# Altium schematic sheet HBridge.SchDoc
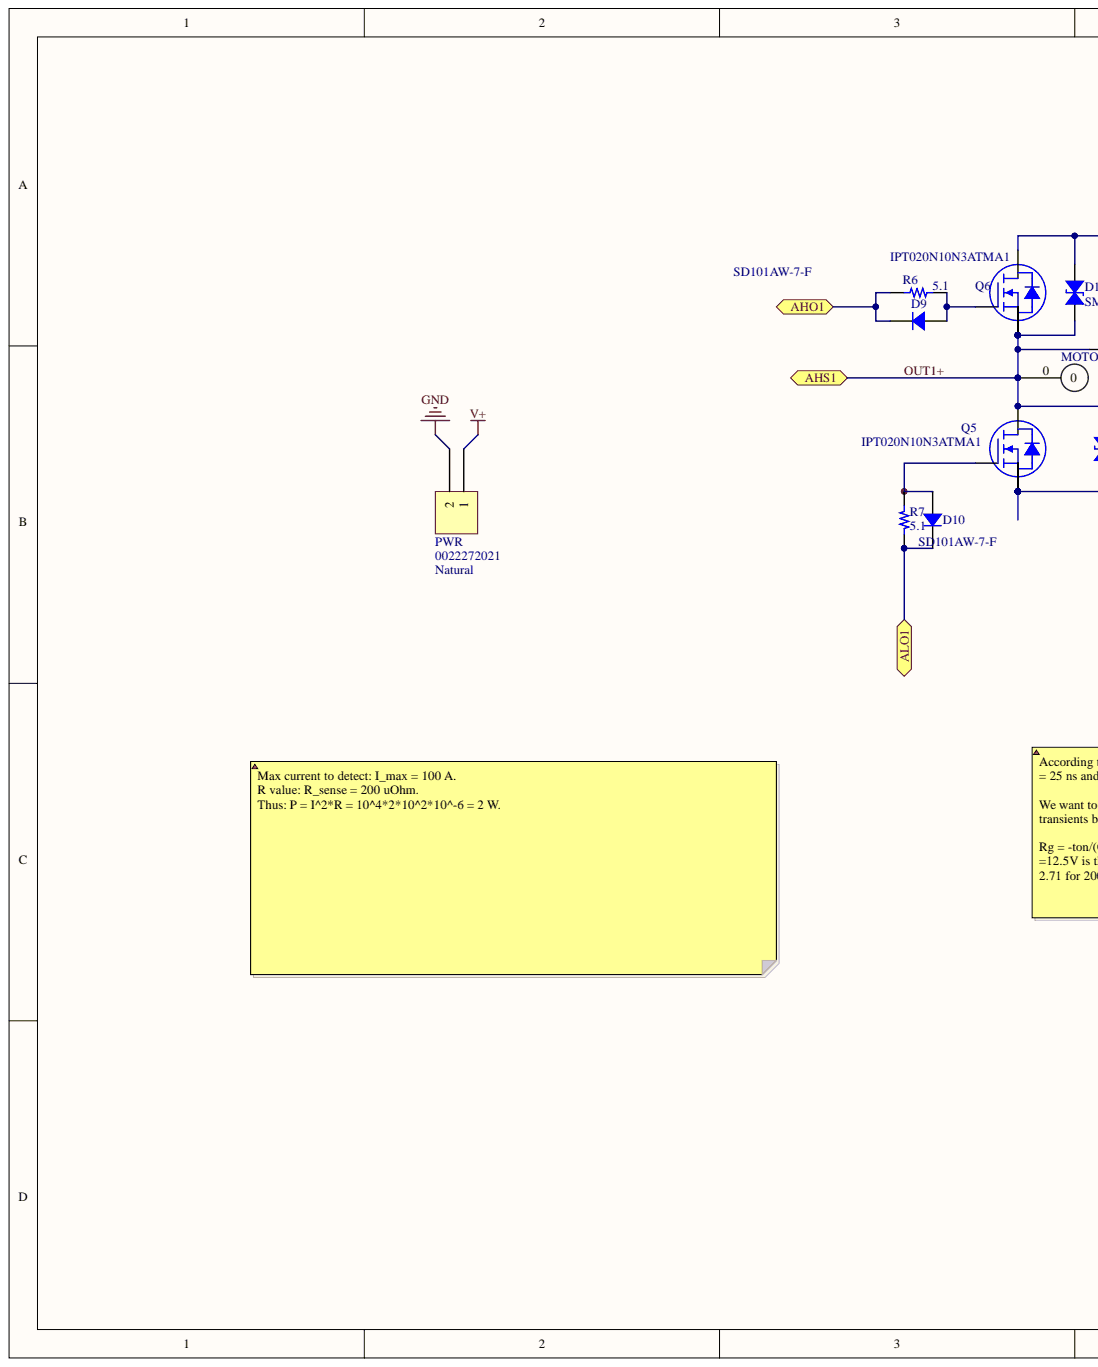
[diagram p0: HBridge.SchDoc, left]
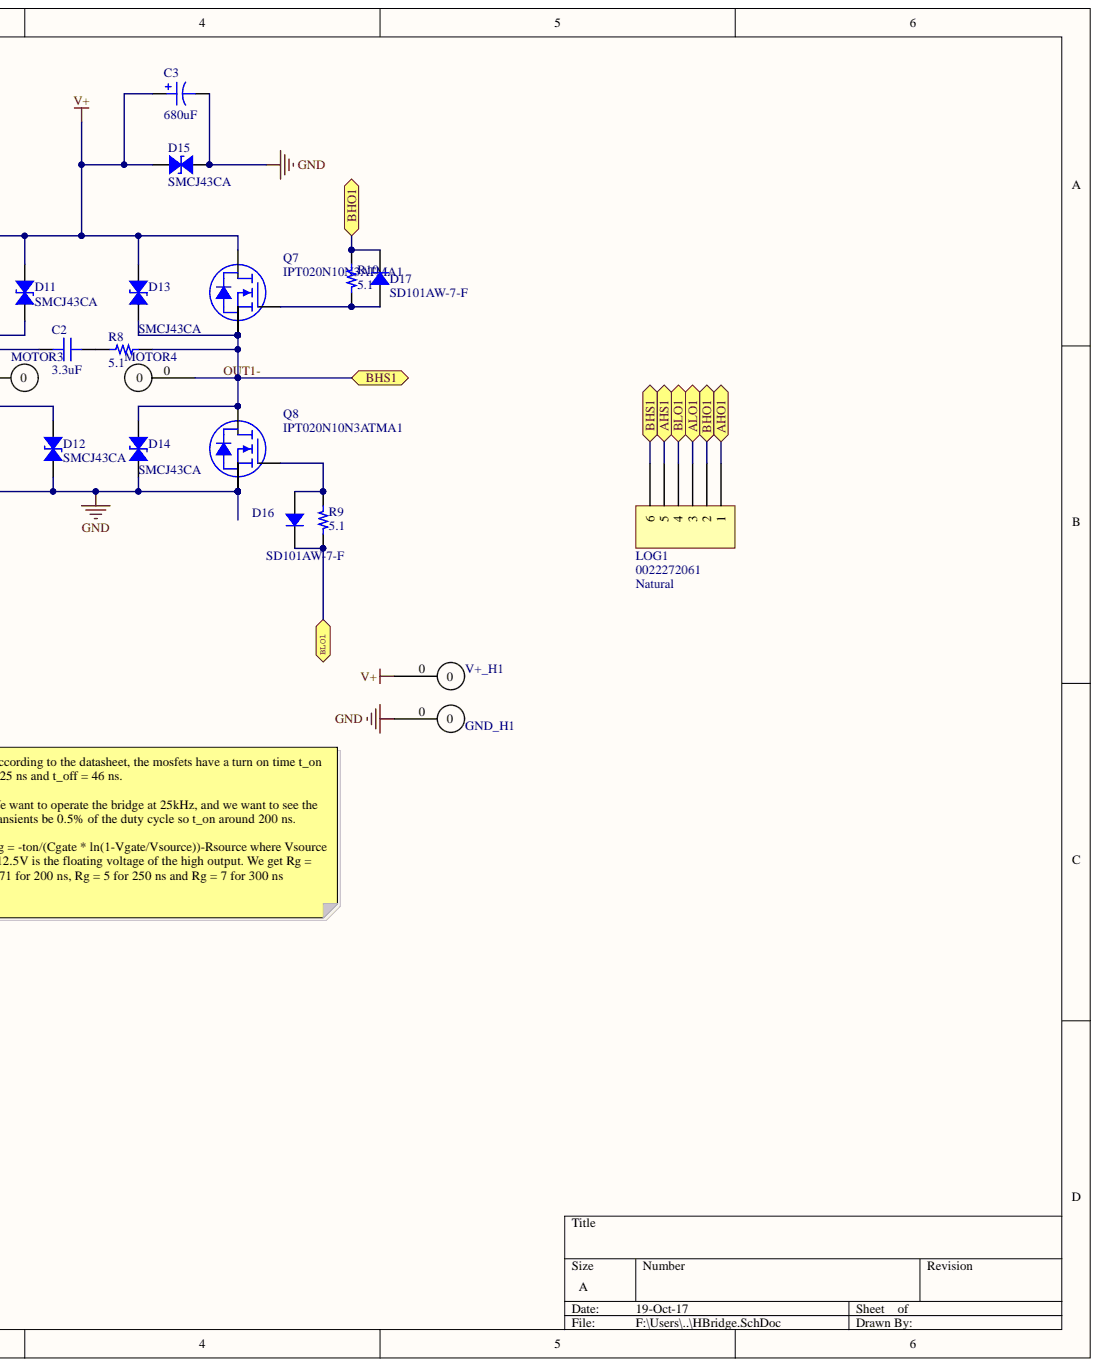
[diagram p1: HBridge.SchDoc, right]

## Parts
C2  3.3uF  [CAP 1206/3216 - 1.8MM]
C3  680uF  [Radial Capacitor 12.5mm]
D9  SD101AW-7-F  [SOD-123]
D10  SD101AW-7-F  [SOD-123]
D11  SMCJ43CA  [ST DO214AB SMC]
D12  SMCJ43CA  [ST DO214AB SMC]
D13  SMCJ43CA  [ST DO214AB SMC]
D14  SMCJ43CA  [ST DO214AB SMC]
D15  SMCJ43CA  [ST DO214AB SMC]
D16  SD101AW-7-F  [SOD-123]
D17  SD101AW-7-F  [SOD-123]
GND_H1  Cable Hole 6.54mm  [Cable Hole 6.54mm]  (value not drawn)
LOG1  0022272061  Colour: Natural  [MOLEX KK 0022272061]
MOTOR3  H1  [Cable Hole 6.54mm]  (value not drawn)
MOTOR4  H2  [Cable Hole 6.54mm]  (value not drawn)
PWR  0022272021  Colour: Natural  [MOLEX KK 0022272021]
Q5  IPT020N10N3ATMA1  [INFINEON PG-HSOF-8-1]
Q6  IPT020N10N3ATMA1  [INFINEON PG-HSOF-8-1]
Q7  IPT020N10N3ATMA1  [INFINEON PG-HSOF-8-1]
Q8  IPT020N10N3ATMA1  [INFINEON PG-HSOF-8-1]
R6  5.1  [RES 1206/3216]
R7  5.1  [RES 1206/3216]
R8  5.1  [RES 1206/3216]
R9  5.1  [RES 1206/3216]
R10  5.1  [RES 1206/3216]
V+_H1  Cable Hole 6.54mm  [Cable Hole 6.54mm]  (value not drawn)

## Ports
AHO1, AHS1, ALO1, BHO1, BHS1, BLO1

## Nets
GND: C3.2 D12.1 D14.1 D15.2 GND_H1.0 PWR.2 Q5.2 Q5.3 Q5.4 Q5.5 Q5.6 Q5.7 Q5.8 Q8.2 Q8.3 Q8.4 Q8.5 Q8.6 Q8.7 Q8.8
V+: C3.1 D11.2 D13.2 D15.1 PWR.1 Q6.9 Q7.9 V+_H1.0
AHO1: D9.2 LOG1.1 R6.1 ; port AHO1
ALO1: D10.2 LOG1.3 R7.2 ; port ALO1
BHO1: D17.2 LOG1.2 R10.1 ; port BHO1
BLO1: D16.2 LOG1.4 R9.2 ; port BLO1
OUT1+: C2.1 D11.1 D12.2 LOG1.5 MOTOR3.0 Q5.9 Q6.2 Q6.3 Q6.4 Q6.5 Q6.6 Q6.7 Q6.8 ; port AHS1
OUT1-: D13.1 D14.2 LOG1.6 MOTOR4.0 Q7.2 Q7.3 Q7.4 Q7.5 Q7.6 Q7.7 Q7.8 Q8.9 R8.2 ; port BHS1
NetC2_2: C2.2 R8.1
NetD9_1: D9.1 Q6.1 R6.2
NetD10_1: D10.1 Q5.1 R7.1
NetD16_1: D16.1 Q8.1 R9.1
NetD17_1: D17.1 Q7.1 R10.2

## Library data of the parts
C2 = CGA5L3X7S2A335K160AB: CAP CER 3.3UF 100V X7S 1206; Manufacturer: TDK Corporation; Database Table Name: Capacitor - Ceramic; TemperatureRange: -55C ~ 125C; Tolerance: 10%; Component Kind: Standard; ComponentLink1Description: Datasheet; ComponentLink1URL: https://product.tdk.com/info/en/catalog/datasheets/mlcc_automotive_midvoltage_en.pdf; ComponentLink2Description: Digi-Key Listing; ComponentLink2URL: https://www.digikey.com/product-detail/en/tdk-corporation/CGA5L3X7S2A335K160AB/445-15583-2-ND/4278316; Device Package: 1206 (3216 Metric); Library Name: Celestial Library Database.DbLib; PartId: 17985; Part Number: CGA5L3X7S2A335K160AB; Price: 0.6319; Supplier 1: Digi-Key; Supplier Part Number 1: 445-15583-2-ND; TemperatureCoefficient: X7S; Value: 3.3uF; Voltage: 100V
C3 = EEUFR1J681L: CAP ALUM 680UF 20% 63V Through Hole; Manufacturer: Panasonic; Database Table Name: Capacitor - Aluminium; Impedance: 21 mOhms; Lifetime @ Temp: 10000 Hrs @ 105°C; Ripple Current: 2.78A @ 100kHz; TemperatureRange: -40C ~ 105C; Type: General Purpose; Component Kind: Standard; ComponentLink1Description: Datasheet; ComponentLink1URL: http://www.farnell.com/datasheets/1897635.pdf?_ga=2.93240925.115427954.1506775348-490126870.1506116288&_gac=1.115494644.1506116288.CjwKCAjw6ZLOBRALEiwAxzyCWz0InfLjFu8mp1t2E94Bo3V2sP4VEUhaMoYFsFDilr1LV; ComponentLink2Description: Farnell Listing; ComponentLink2URL: http://fr.farnell.com/panasonic-electronic-components/eeufr1j681l/condensateur-elec-alu-680uf-63v/dp/2079311; Device Package: Radial, Can; Library Name: Celestial Library Database.DbLib; PartId: 7484; Part Number: EEUFR1J681L; Price: 1.93; Supplier 1: Farnell; Supplier Part Number 1: 2079311; Tolerance: 20%; Value: 680uF; Voltage: 63V
D9 D10 D16 D17 = SD101AW-7-F: DIODE SCHOTTKY 60V 15MA SOD123; Manufacturer: Diodes Incorporated; Current Rectified: 15mA (DC); Current Reverse Leakage @ Vr: 200nA @ 50V; Database Table Name: Diode - Rectifier; Reverse Recovery Time (trr): 1ns; Voltage Forward (Vf): 1V @ 15mA; Component Kind: Standard; ComponentLink1Description: Datasheet; ComponentLink1URL: http://www.diodes.com/_files/datasheets/ds11012.pdf; ComponentLink2Description: Digi-Key Listing; ComponentLink2URL: https://www.digikey.com/product-detail/en/diodes-incorporated/SD101AW-7-F/SD101AW-7FDITR-ND/1155357; Device Package: SOD-123; Junction Operating Temperature: -65C ~ 125C; Library Name: Celestial Library Database.DbLib; PartId: 14608; Part Number: SD101AW-7-F; Price: 0.081; Supplier 1: Digi-Key; Supplier Part Number 1: SD101AW-7FDITR-ND; Type: Schottky; Voltage DC Reverse (Vr): 60V
D11-D15 = SMCJ43CA: TVS DIODE 43VWM 69.4VC SMC; Manufacturer: FAIRCHILD; Bidirectional Channels: 1; Current - Peak Pulse: 21.6 (10/1000); Database Table Name: Diode - TVS; Power - Peak Pulse: 1500W (1.5kW); Type: Zener; Voltage (Breakdown): 52.8V; Voltage (Clamping): 69.4V; Voltage (Reverse Standoff): 43V; Component Kind: Standard; ComponentLink1Description: Datasheet; ComponentLink1URL: https://www.fairchildsemi.com/datasheets/SM/SMCJ100A.pdf; ComponentLink2Description: Farnell Listing; ComponentLink2URL: http://fr.farnell.com/on-semiconductor-fairchild/smcj43ca/diode-tvs/dp/1651632; Device Package: DO-214AB, SMC; Library Name: Celestial Library Database.DbLib; Operating Temperature: -55C ~ 150C (TJ); PartId: 14960; Part Number: SMCJ43CA; Price: 0.492; Supplier 1: Farnell; Supplier Part Number 1: 1651632
GND_H1 MOTOR3 MOTOR4 V+_H1 = Cable Pad AWG 2: Database Table Name: Connector - Card Edge; Number of Positions: 1; Component Kind: Standard; Library Name: Celestial Library Database.DbLib; PartId: 10977; Part Number: Cable Pad AWG 2
LOG1 = 0022272061: CONN HEADER 6POS .100 VERT TIN; Manufacturer: Molex, LLC; Database Table Name: Connector - Rectangular; Number Of Positions: 6; Pitch: 0.100" (2.54mm); Component Kind: Standard; ComponentLink1Description: Datasheet; ComponentLink1URL: http://www.molex.com/pdm_docs/sd/022272061_sd.pdf; ComponentLink2Description: Digi-Key Listing; ComponentLink2URL: http://www.digikey.com/product-search/en?keywords=22-27-2061; Contact Finish: Tin; Fastening Type: Friction Lock; Library Name: Celestial Library Database.DbLib; Number Of Rows: 1; PartId: 9723; Part Number: 0022272061; Price: 0.47; Series: KK 6410; Supplier 1: Digi-Key; Supplier Part Number 1: WM4115-ND; Type: Header, Unshrouded
PWR = 0022272021: CONN HEADER 2POS .100 VERT TIN; Manufacturer: Molex, LLC; Database Table Name: Connector - Rectangular; Number Of Positions: 2; Pitch: 0.100" (2.54mm); Component Kind: Standard; ComponentLink1Description: Datasheet; ComponentLink1URL: http://www.molex.com/pdm_docs/sd/022272021_sd.pdf; ComponentLink2Description: Digi-Key Listing; ComponentLink2URL: http://www.digikey.com/product-search/en?keywords=22-27-2021; Contact Finish: Tin; Fastening Type: Friction Lock; Library Name: Celestial Library Database.DbLib; Number Of Rows: 1; PartId: 9719; Part Number: 0022272021; Price: 0.25; Series: KK 6410; Supplier 1: Digi-Key; Supplier Part Number 1: WM4111-ND; Type: Header, Unshrouded
Q5-Q8 = IPT020N10N3ATMA1: MOSFET N-CH 100V 300A 8HSOF; Manufacturer: Infineon Technologies; Current Continuous Drain: 300A (Tc); Database Table Name: N-Channel FET; Gate Charge (Qg): 156nC @ 10V; Input Capacitance (Ciss) at Vds: 11200pF @ 50V; Power - Max: 375W; Rds On (Max) at Id, Vgs: 2 mOhm @ 150A, 10V; Type: MOSFET N-Channel, Metal Oxide; Vgs(th) (Max) at Id: 3.5V @ 272uA; Component Kind: Standard; ComponentLink1Description: Datasheet; ComponentLink1URL: http://www.infineon.com/dgdl/IPT020N10N3_Rev1.1.pdf?folderId=db3a304313b8b5a60113cee8763b02d7&fileId=db3a30433e9d5d11013e9e58035b0158; ComponentLink2Description: Digi-Key Listing; ComponentLink2URL: http://www.digikey.com/product-detail/en/IPT020N10N3ATMA1/IPT020N10N3ATMA1TR-ND/4571872; Device Package: 8-PowerSFN; Drain to Source Voltage (Vdss): 100V; Features: Standard; Library Name: Celestial Library Database.DbLib; PartId: 7970; Part Number: IPT020N10N3ATMA1; Price: 3.3442; Supplier 1: Digi-Key; Supplier Part Number 1: IPT020N10N3ATMA1TR-ND
R6-R10 = ERJ-8GEYJ5R1V: RES SMD 5.1 OHM 5% 1/4W 1206; Manufacturer: Panasonic Electronic Components; Database Table Name: Resistor - Chip; PowerRating: 0.25W, 1/4W; TemperatureCoefficient: -100/ +600ppm/C; TemperatureRange: -55C ~ 155C; Component Kind: Standard; ComponentLink1Description: Datasheet; ComponentLink1URL: http://industrial.panasonic.com/www-cgi/jvcr13pz.cgi?E+PZ+3+AOA0001+ERJ8GEYJ5R1V+7+WW; ComponentLink2Description: Digi-Key Listing; ComponentLink2URL: https://www.digikey.com/product-detail/en/panasonic-electronic-components/ERJ-8GEYJ5R1V/P5.1ETR-ND/282211; Device Package: 1206 (3216 Metric); Library Name: Celestial Library Database.DbLib; PartId: 11913; Part Number: ERJ-8GEYJ5R1V; Price: 0.0045; Supplier 1: Digi-Key; Supplier Part Number 1: P5.1ETR-ND; Tolerance: 5%; Value: 5.1
